# Altium schematic sheet Thermistor Channel.SchDoc
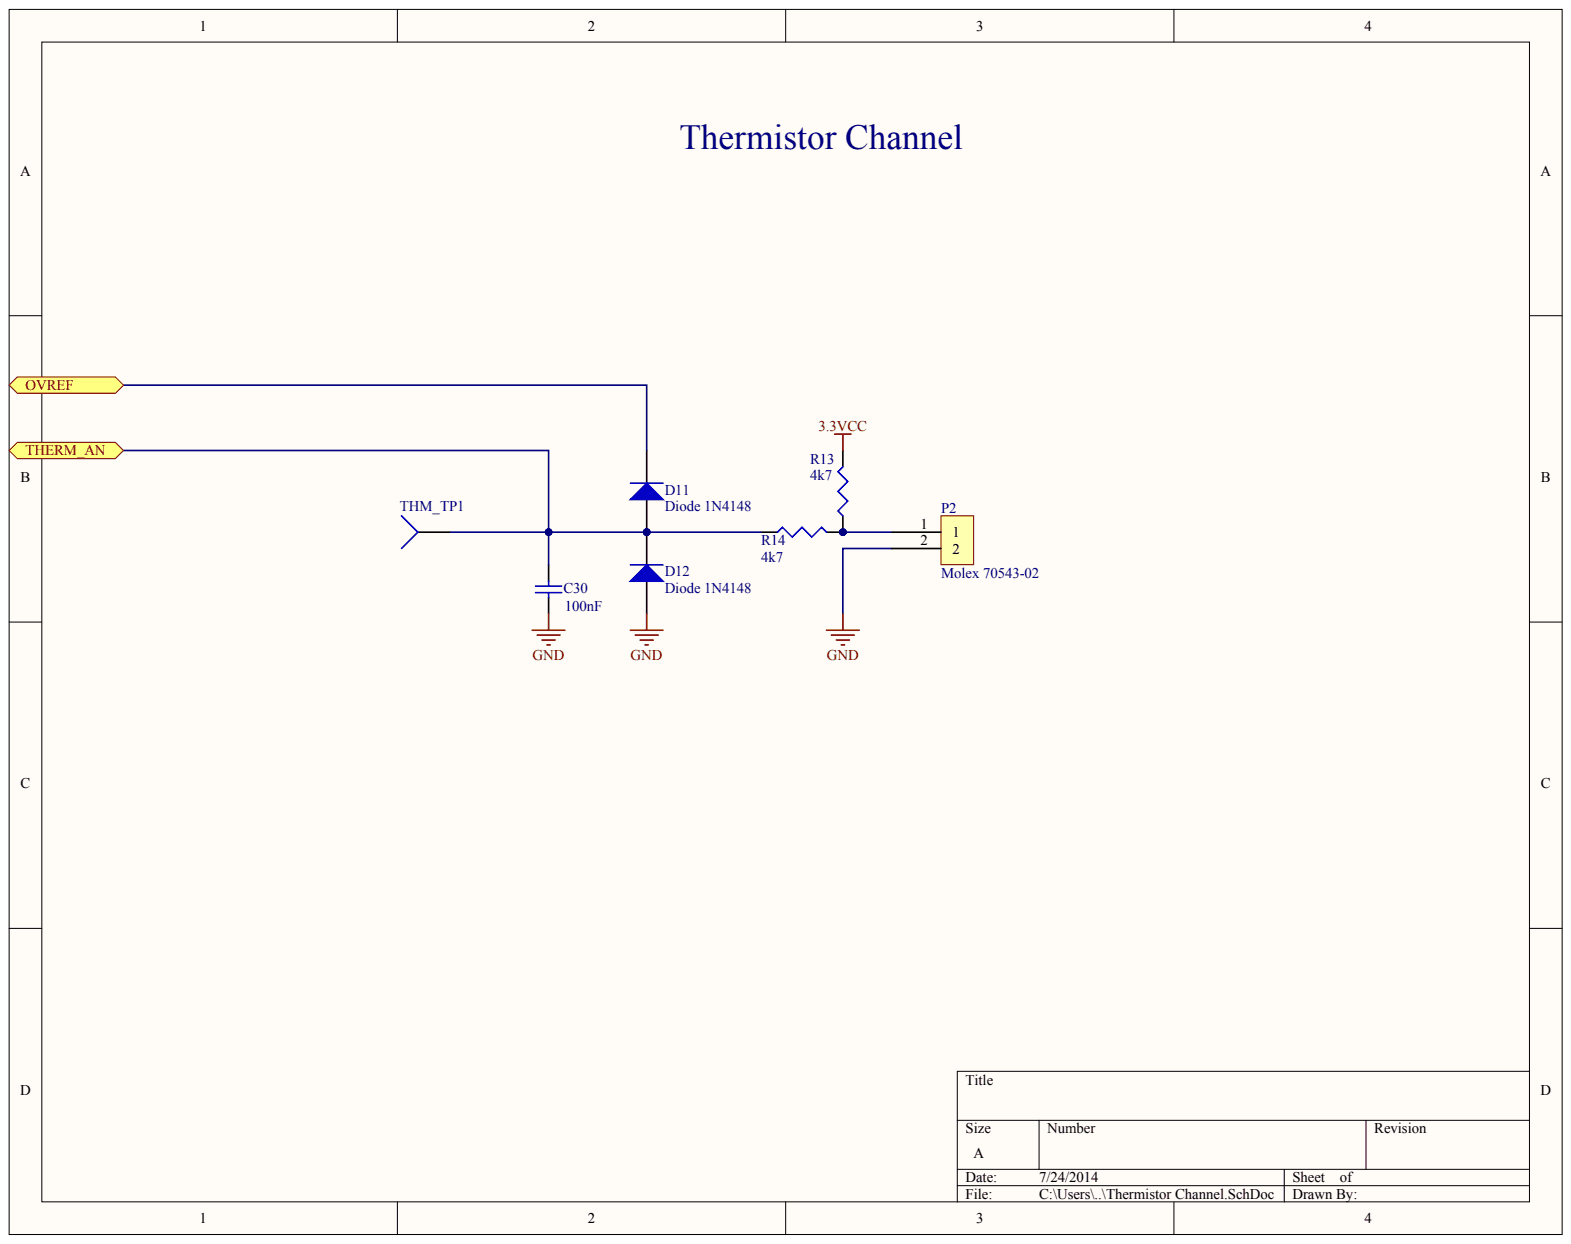
[diagram p0: Thermistor Channel.SchDoc]

## Parts
C30  value 100nF  (hidden comment: Cap Semi)
D11  Diode 1N4148
D12  Diode 1N4148
P2  Molex 70543-02
R13  value 4k7  (hidden comment: Res3)
R14  value 4k7  (hidden comment: Res3)
THM_TP1  TP4  (value not drawn)

## Ports
OVREF, THERM_AN

## Nets
3.3VCC: R13.2
GND: C30.1 D12.1 P2.2
OVREF: D11.2 ; port OVREF
THERM_AN: C30.2 D11.1 D12.2 R14.1 THM_TP1.1 ; port THERM_AN
NetP2_1: P2.1 R13.1 R14.2

## Library data of the parts
C30 D11 D12 P2 R13 R14 THM_TP1 = (no symbol name)
  C30: Published: 8-Jun-2000; LatestRevisionDate: 29-May-2009; LatestRevisionNote: IPC-7351 Footprint Added.; PackageReference: C1206; PackageDescription: Chip Capacitor
  D11 D12: Published: 8-Jun-2000; LatestRevisionDate: 17-Jul-2002; LatestRevisionNote: Re-released for DXP Platform.; DatasheetVersion: 1997; PackageReference: DO-35; PackageDescription: Diode, Axial; Body 3.8 x 1.9 mm (LxDia. max), Lead Dia. 0.53 mm (max); Code_JEDEC: DO-204-AH; ComponentLink1Description: Manufacturer Link; ComponentLink1URL: http://www.fairchildsemi.com/; ComponentLink2Description: Datasheet; ComponentLink2URL: http://www.fairchildsemi.com/ds/1N%2F1N4148.pdf
  R13 R14: Published: 8-Jun-2000; LatestRevisionDate: 29-May-2009; LatestRevisionNote: IPC-7351 Footprint Added.; PackageReference: J1-0603; PackageDescription: Chip Resistor
  THM_TP1: LatestRevisionDate: 17-Jul-2002; LatestRevisionNote: Re-released for DXP Platform.
  C30 D11 D12 R13 R14 THM_TP1: Publisher: Altium Limited
